# Altium schematic sheet INA260.SchDoc(INA260)
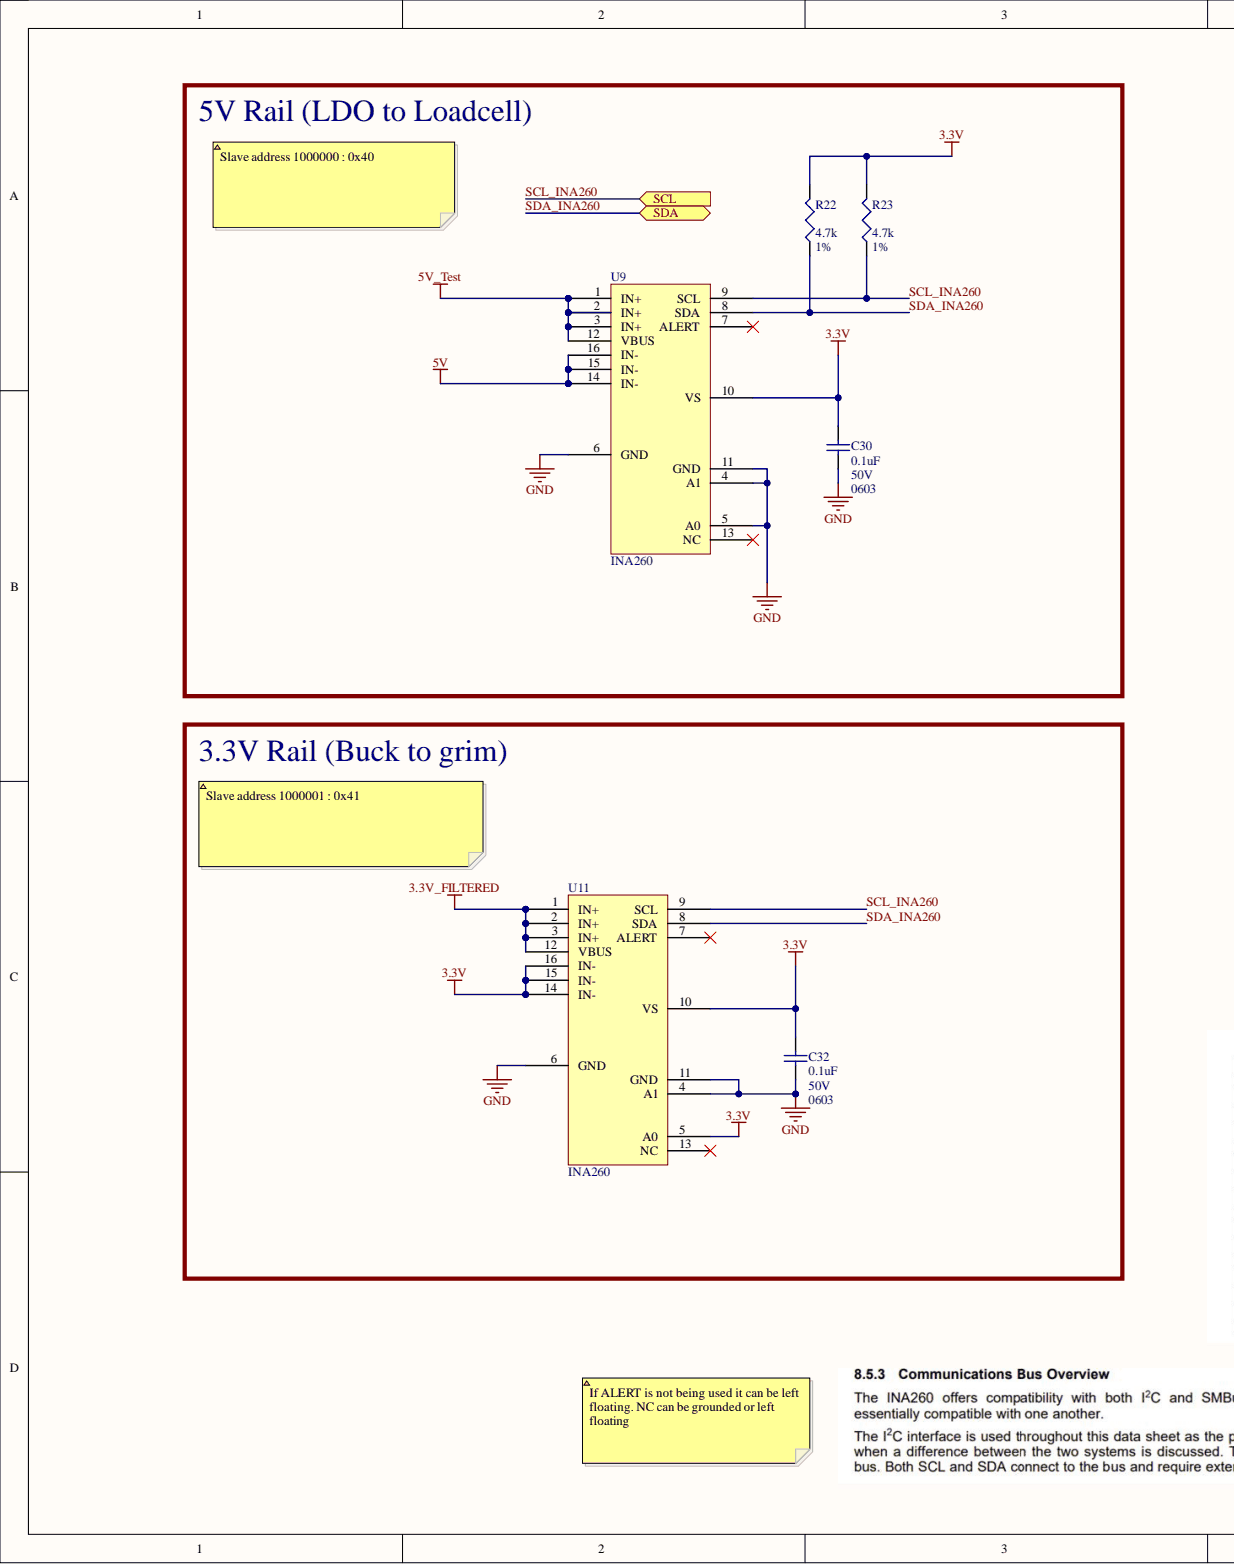
[diagram p0: INA260.SchDoc, left]
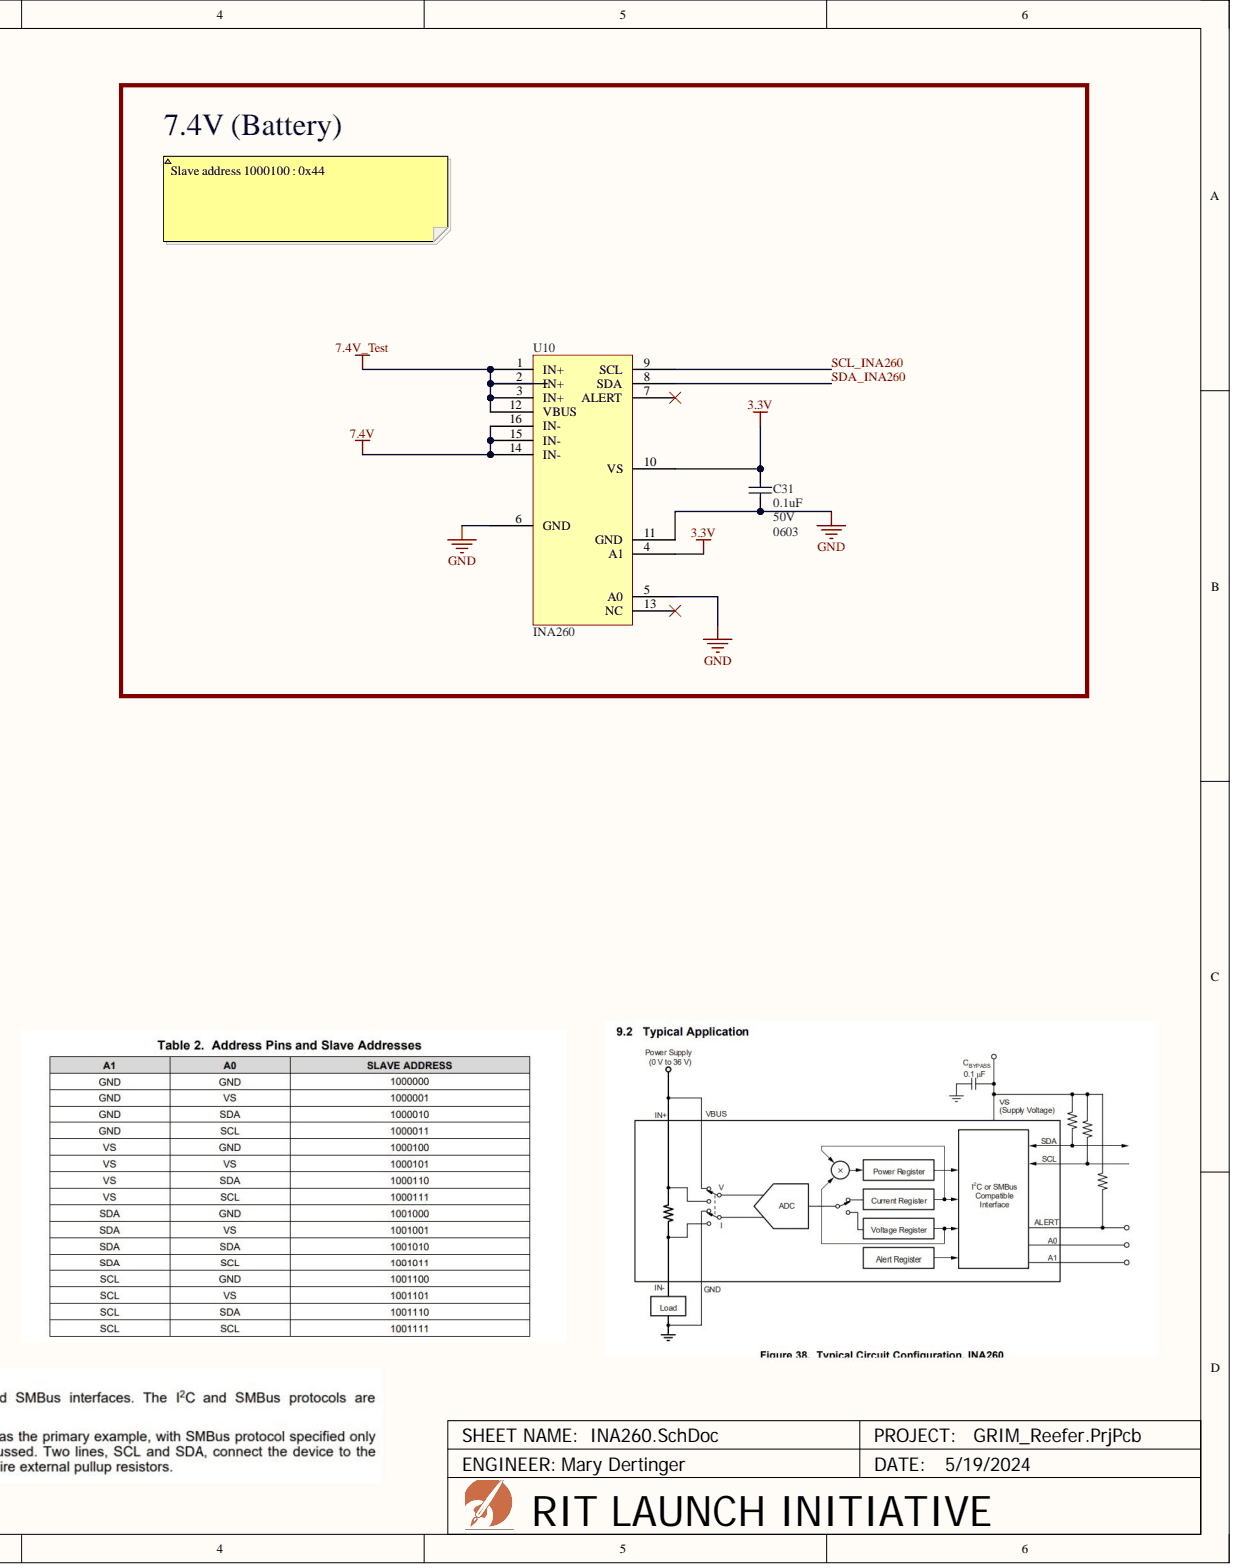
[diagram p1: INA260.SchDoc, right]

## Parts
C30  Capacitance: 0.1uF  Case Code (Imperial): 0603  Voltage: 50V  (hidden comment: CC0603KRX7R9BB104)
C31  Capacitance: 0.1uF  Case Code (Imperial): 0603  Voltage: 50V  (hidden comment: CC0603KRX7R9BB104)
C32  Capacitance: 0.1uF  Case Code (Imperial): 0603  Voltage: 50V  (hidden comment: CC0603KRX7R9BB104)
R22  Resistance: 4.7k  Tolerance: 1%  (hidden comment: 0603WAF4701T5E)
R23  Resistance: 4.7k  Tolerance: 1%  (hidden comment: 0603WAF4701T5E)
U9  INA260
U10  INA260  Nominal Supply Voltage: 3.3V
U11  INA260  Nominal Supply Voltage: 3.3V

## Ports
SCL, SDA

## Nets
3.3V: C30.2 C31.2 C32.2 R22.2 R23.2 U9.10(VS) U10.4(A1) U10.10(VS) U11.5(A0) U11.10(VS) U11.14(IN-) U11.15(IN-) U11.16(IN-)
3.3V_FILTERED: U11.1(IN+) U11.2(IN+) U11.3(IN+) U11.12(VBUS)
5V: U9.14(IN-) U9.15(IN-) U9.16(IN-)
5V_Test: U9.1(IN+) U9.2(IN+) U9.3(IN+) U9.12(VBUS)
7.4V: U10.14(IN-) U10.15(IN-) U10.16(IN-)
7.4V_Test: U10.1(IN+) U10.2(IN+) U10.3(IN+) U10.12(VBUS)
GND: C30.1 C31.1 C32.1 U9.4(A1) U9.5(A0) U9.6(GND) U9.11(GND) U10.5(A0) U10.6(GND) U10.11(GND) U11.4(A1) U11.6(GND) U11.11(GND)
SCL_INA260: R23.1 U9.9(SCL) U10.9(SCL) U11.9(SCL) ; port SCL
SDA_INA260: R22.1 U9.8(SDA) U10.8(SDA) U11.8(SDA) ; port SDA

Not connected: U9 7(ALERT) 13(NC); U10 7(ALERT) 13(NC); U11 7(ALERT) 13(NC)

## Library data of the parts
C30-C32 = CMP-001-0242-3: CAP CER 0.1UF 50V X7R 0603; Dielectric Material: Ceramic; Tolerance: 10%; Case Code (Metric): 1608; Case/Package: 0603; Component Kind: Standard; Depth: 0.8mm; Dielectric: X7R; Halogen Free: Halogen Free; Height: 0.8mm; JLCPCB Part #: C14663; Lead Free: Lead Free; Length: 0.063inch; Max Operating Temperature: 125°C; Min Operating Temperature: -55°C; Mount: Surface Mount; Number of Pins: 2; Package Quantity: 1; Packaging: Tape and Reel; Radiation Hardening: No; REACH SVHC: No SVHC; RoHS Compliant: Yes; Series: CC; Termination: SMD/SMT; Thickness: 0.035inch; Voltage Rating: 50V; Width: 0.031inch; Library Name: RIT Launch Initiative
R22 R23 = CMP-001-0283-3: 100mW Thick Film Resistors 75V ±100ppm/℃ ±1% -55℃~+155℃ 4.7kΩ 0603 Chip Resistor - Surface Mount ROHS; Component Kind: Standard; JLCPCB Part #: C23162; Package: 0603; Library Name: RIT Launch Initiative
U9 = CMP-001-0376-2: Operational Amplifier, 1 Func, 7500uV Offset-Max, PDSO16; Adjustable Threshold: No; Channels: 1; Max Supply Voltage: 5.5V; Min Supply Voltage: 2.7V; Nominal Supply Voltage: 3.3V; Package Body Material: Plastic; Throw Configuration: POWER SUPPLY SUPPORT CIRCUIT; Case/Package: TSSOP; Component Kind: Standard; Height - Seated (Max): 1.2mm; JESD-30 Code: R-PDSO-G16; JESD-609 Code: e4; JLCPCB Part #: C2155885; Length: 5mm; Max Operating Temperature: 125°C; Min Operating Temperature: -40°C; Moisture Sensitivity Level: 2; Number of Channels: 1; Package Shape: Rectangular; Package Style: SMALL OUTLINE, THIN PROFILE, SHRINK PITCHMeter; Pins: 16; Reach SVHC Compliant: Yes; RoHS Compliant: Yes; Surface Mount: Yes; Temperature Grade: AUTOMOTIVE; Terminal Finish: Nickel; Terminal Form: Gull Wing; Terminal Pitch: 0.65mm; Terminal Position: DUAL; Width: 4.4mm; Library Name: RIT Launch Initiative
U10 U11 = CMP-001-0376-2: Operational Amplifier, 1 Func, 7500uV Offset-Max, PDSO16; Adjustable Threshold: No; Channels: 1; Max Supply Voltage: 5.5V; Min Supply Voltage: 2.7V; Package Body Material: Plastic; Throw Configuration: POWER SUPPLY SUPPORT CIRCUIT; Case/Package: TSSOP; Component Kind: Standard; Height - Seated (Max): 1.2mm; JESD-30 Code: R-PDSO-G16; JESD-609 Code: e4; JLCPCB Part #: C2155885; Length: 5mm; Max Operating Temperature: 125°C; Min Operating Temperature: -40°C; Moisture Sensitivity Level: 2; Number of Channels: 1; Package Shape: Rectangular; Package Style: SMALL OUTLINE, THIN PROFILE, SHRINK PITCHMeter; Pins: 16; Reach SVHC Compliant: Yes; RoHS Compliant: Yes; Surface Mount: Yes; Temperature Grade: AUTOMOTIVE; Terminal Finish: Nickel; Terminal Form: Gull Wing; Terminal Pitch: 0.65mm; Terminal Position: DUAL; Width: 4.4mm; Library Name: RIT Launch Initiative
